# Altium schematic sheet igbt_output.SchDoc
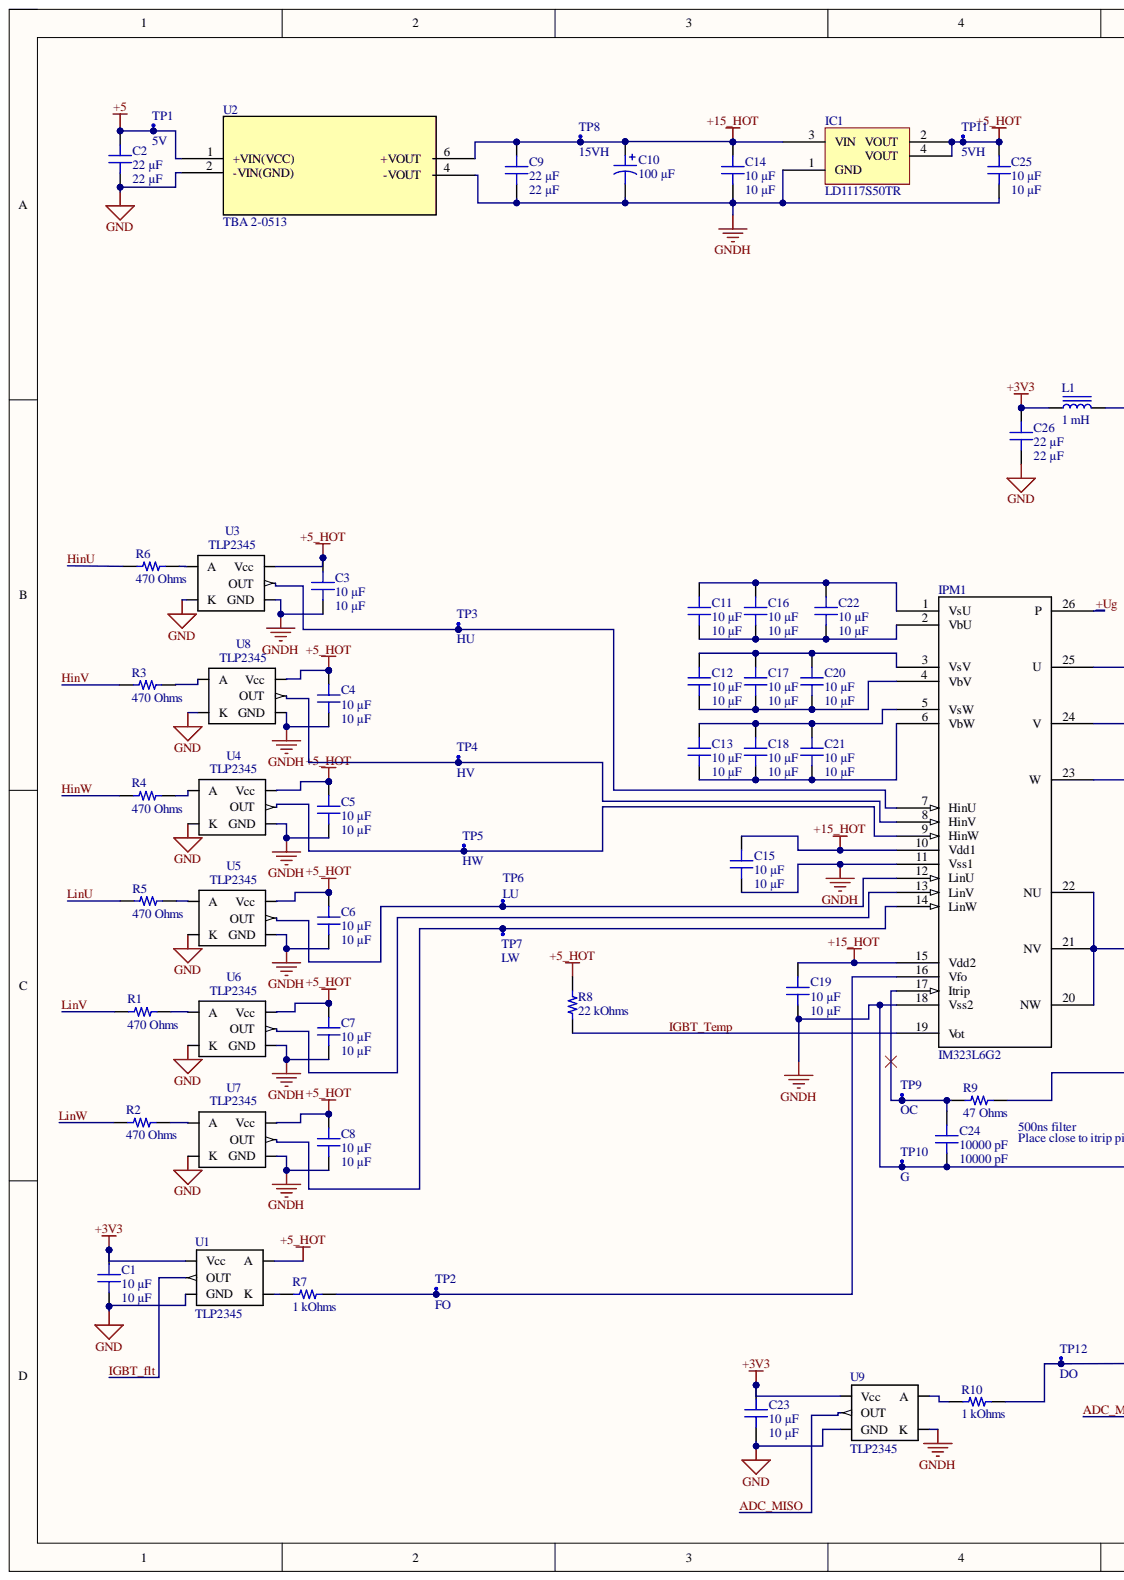
[diagram p0: igbt_output.SchDoc, left]
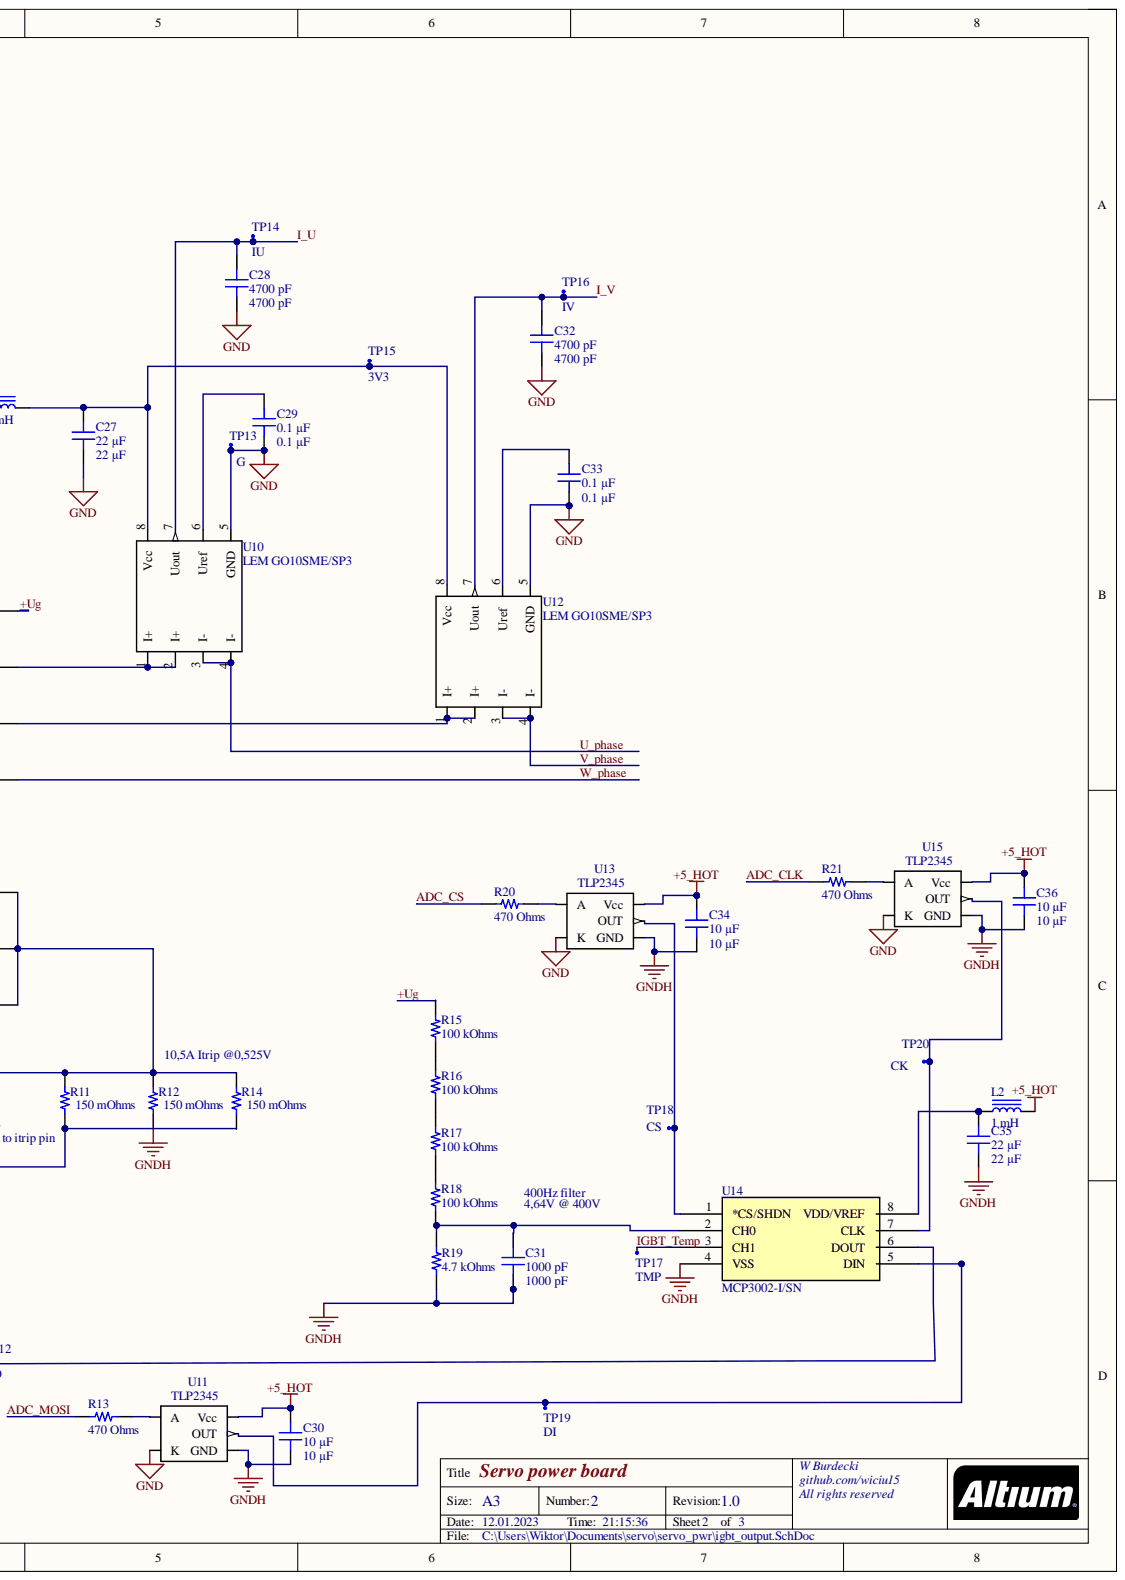
[diagram p1: igbt_output.SchDoc, right]

## Parts
C1  10 µF  [CAP 0805_2012]
C2  22 µF  [CAP 0805_2012]
C3  10 µF  [CAP 0805_2012]
C4  10 µF  [CAP 0805_2012]
C5  10 µF  [CAP 0805_2012]
C6  10 µF  [CAP 0805_2012]
C7  10 µF  [CAP 0805_2012]
C8  10 µF  [CAP 0805_2012]
C9  22 µF  [CAP 0805_2012]
C10  100 µF  [CAP AL TH D8 L3.5 H12.5]
C11  10 µF  [CAP 0805_2012]
C12  10 µF  [CAP 0805_2012]
C13  10 µF  [CAP 0805_2012]
C14  10 µF  [CAP 0805_2012]
C15  10 µF  [CAP 0805_2012]
C16  10 µF  [CAP 0805_2012]
C17  10 µF  [CAP 0805_2012]
C18  10 µF  [CAP 0805_2012]
C19  10 µF  [CAP 0805_2012]
C20  10 µF  [CAP 0805_2012]
C21  10 µF  [CAP 0805_2012]
C22  10 µF  [CAP 0805_2012]
C23  10 µF  [CAP 0805_2012]
C24  10000 pF  [CAP 0805_2012]
C25  10 µF  [CAP 0805_2012]
C26  22 µF  [CAP 0805_2012]
C27  22 µF  [CAP 0805_2012]
C28  4700 pF  [CAP 0805_2012]
C29  0.1 µF  [CAP 0805_2012]
C30  10 µF  [CAP 0805_2012]
C31  1000 pF  [CAP 0805_2012]
C32  4700 pF  [CAP 0805_2012]
C33  0.1 µF  [CAP 0805_2012]
C34  10 µF  [CAP 0805_2012]
C35  22 µF  [CAP 0805_2012]
C36  10 µF  [CAP 0805_2012]
IC1  LD1117S50TR  [ST SOT-223]
IPM1  IM323L6G2  [IM323L6G2]
L1  1 mH  [BOURNS SRR6028]
L2  1 mH  [BOURNS SRR6028]
R1  470 Ohms  [RES 0805_2012]
R2  470 Ohms  [RES 0805_2012]
R3  470 Ohms  [RES 0805_2012]
R4  470 Ohms  [RES 0805_2012]
R5  470 Ohms  [RES 0805_2012]
R6  470 Ohms  [RES 0805_2012]
R7  1 kOhms  [RES 0805_2012]
R8  22 kOhms  [RES 0805_2012]
R9  47 Ohms  [RES 0805_2012]
R10  1 kOhms  [RES 0805_2012]
R11  150 mOhms  [RES 2512_6432]
R12  150 mOhms  [RES 2512_6432]
R13  470 Ohms  [RES 0805_2012]
R14  150 mOhms  [RES 2512_6432]
R15  100 kOhms  [RES 1206_3216]
R16  100 kOhms  [RES 1206_3216]
R17  100 kOhms  [RES 1206_3216]
R18  100 kOhms  [RES 1206_3216]
R19  4.7 kOhms  [RES 1206_3216]
R20  470 Ohms  [RES 0805_2012]
R21  470 Ohms  [RES 0805_2012]
TP1  5V  [Testpoint1,2mm]
TP2  FO  [Testpoint1,2mm]
TP3  HU  [Testpoint1,2mm]
TP4  HV  [Testpoint1,2mm]
TP5  HW  [Testpoint1,2mm]
TP6  LU  [Testpoint1,2mm]
TP7  LW  [Testpoint1,2mm]
TP8  15VH  [Testpoint1,2mm]
TP9  OC  [Testpoint1,2mm]
TP10  G  [Testpoint1,2mm]
TP11  5VH  [Testpoint1,2mm]
TP12  DO  [Testpoint1,2mm]
TP13  G  [Testpoint1,2mm]
TP14  IU  [Testpoint1,2mm]
TP15  3V3  [Testpoint1,2mm]
TP16  IV  [Testpoint1,2mm]
TP17  TMP  [Testpoint1,2mm]
TP18  CS  [Testpoint1,2mm]
TP19  DI  [Testpoint1,2mm]
TP20  CK  [Testpoint1,2mm]
U1  TLP2345  [TLP2345]
U2  TBA 2-0513  [TBA 2_SINGLE_TRP]
U3  TLP2345  [TLP2345]
U4  TLP2345  [TLP2345]
U5  TLP2345  [TLP2345]
U6  TLP2345  [TLP2345]
U7  TLP2345  [TLP2345]
U8  TLP2345  [TLP2345]
U9  TLP2345  [TLP2345]
U10  LEM GO10SME/SP3  [TSOP127P600X160-8N]
U11  TLP2345  [TLP2345]
U12  LEM GO10SME/SP3  [TSOP127P600X160-8N]
U13  TLP2345  [TLP2345]
U14  MCP3002-I/SN  [SOIC8-N_MC_MCH]
U15  TLP2345  [TLP2345]

## Nets
+3V3: C1.2 C23.2 C26.2 L1.1 U1.6(Vcc) U9.6(Vcc)
+5: C2.2 TP1.1 U2.1(+VIN(VCC))
+5_HOT: C3.2 C4.2 C5.2 C6.2 C7.2 C8.2 C25.2 C30.2 C34.2 C36.2 IC1.2(VOUT) IC1.4(VOUT) L2.2 R8.2 TP11.1 U1.1(A) U3.6(Vcc) U4.6(Vcc) U5.6(Vcc) U6.6(Vcc) U7.6(Vcc) U8.6(Vcc) U11.6(Vcc) U13.6(Vcc) U15.6(Vcc)
+15_HOT: C9.2 C10.1 C14.2 C15.2 C19.2 IC1.3(VIN) IPM1.10(Vdd1) IPM1.15(Vdd2) TP8.1 U2.6(+VOUT)
GND: C1.1 C2.1 C23.1 C26.1 C27.1 C28.1 C29.1 C32.1 C33.1 TP13.1 U1.4(GND) U2.2(-VIN(GND)) U3.3(K) U4.3(K) U5.3(K) U6.3(K) U7.3(K) U8.3(K) U9.4(GND) U10.5(GND) U11.3(K) U12.5(GND) U13.3(K) U15.3(K)
GNDH: C3.1 C4.1 C5.1 C6.1 C7.1 C8.1 C9.1 C10.2 C14.1 C15.1 C19.1 C24.1 C25.1 C30.1 C31.1 C34.1 C35.1 C36.1 IC1.1(GND) IPM1.11(Vss1) IPM1.18(Vss2) R11.1 R12.1 R14.1 R19.1 TP10.1 U2.4(-VOUT) U3.4(GND) U4.4(GND) U5.4(GND) U6.4(GND) U7.4(GND) U8.4(GND) U9.3(K) U11.4(GND) U13.4(GND) U14.4(VSS) U15.4(GND)
+Ug: IPM1.26(P) R15.2
ADC_CLK: R21.1
ADC_CS: R20.1
ADC_MISO: U9.5(OUT)
ADC_MOSI: R13.1
HinU: R6.1
HinV: R3.1
HinW: R4.1
I_U: C28.2 TP14.1 U10.7(Uout)
I_V: C32.2 TP16.1 U12.7(Uout)
IGBT_flt: U1.5(OUT)
IGBT_Temp: IPM1.19(Vot) R8.1 TP17.1 U14.3(CH1)
LinU: R5.1
LinV: R1.1
LinW: R2.1
U_phase: U10.3(I-) U10.4(I-)
V_phase: U12.3(I-) U12.4(I-)
W_phase: IPM1.23(W)
NetC11_1: C11.1 C16.1 C22.1 IPM1.2(VbU)
NetC11_2: C11.2 C16.2 C22.2 IPM1.1(VsU)
NetC12_1: C12.1 C17.1 C20.1 IPM1.4(VbV)
NetC12_2: C12.2 C17.2 C20.2 IPM1.3(VsV)
NetC13_1: C13.1 C18.1 C21.1 IPM1.6(VbW)
NetC13_2: C13.2 C18.2 C21.2 IPM1.5(VsW)
NetC24_2: C24.2 IPM1.17(Itrip) R9.1 TP9.1
NetC27_2: C27.2 L1.2 TP15.1 U10.8(Vcc) U12.8(Vcc)
NetC29_2: C29.2 U10.6(Uref)
NetC31_2: C31.2 R18.1 R19.2 U14.2(CH0)
NetC33_2: C33.2 U12.6(Uref)
NetC35_2: C35.2 L2.1 U14.8(VDD/VREF)
NetIPM1_7: IPM1.7(HinU) TP3.1 U3.5(OUT)
NetIPM1_8: IPM1.8(HinV) TP4.1 U8.5(OUT)
NetIPM1_9: IPM1.9(HinW) TP5.1 U4.5(OUT)
NetIPM1_12: IPM1.12(LinU) TP6.1 U5.5(OUT)
NetIPM1_13: IPM1.13(LinV) U6.5(OUT)
NetIPM1_14: IPM1.14(LinW) TP7.1 U7.5(OUT)
NetIPM1_16: IPM1.16(Vfo) R7.2 TP2.1
NetIPM1_20: IPM1.20(NW) IPM1.21(NV) IPM1.22(NU) R9.2 R11.2 R12.2 R14.2
NetIPM1_24: IPM1.24(V) U12.1(I+) U12.2(I+)
NetIPM1_25: IPM1.25(U) U10.1(I+) U10.2(I+)
NetR1_2: R1.2 U6.1(A)
NetR2_2: R2.2 U7.1(A)
NetR3_2: R3.2 U8.1(A)
NetR4_2: R4.2 U4.1(A)
NetR5_2: R5.2 U5.1(A)
NetR6_2: R6.2 U3.1(A)
NetR7_1: R7.1 U1.3(K)
NetR10_1: R10.1 U9.1(A)
NetR10_2: R10.2 TP12.1 U14.6(DOUT)
NetR13_2: R13.2 U11.1(A)
NetR15_1: R15.1 R16.2
NetR16_1: R16.1 R17.2
NetR17_1: R17.1 R18.2
NetR20_2: R20.2 U13.1(A)
NetR21_2: R21.2 U15.1(A)
NetTP18_1: TP18.1 U13.5(OUT) U14.1(*CS/SHDN)
NetTP19_1: TP19.1 U11.5(OUT) U14.5(DIN)
NetTP20_1: TP20.1 U14.7(CLK) U15.5(OUT)

## Library data of the parts
C1 C3-C8 C11-C23 C25 C30 C34 C36 = LMK212ABJ106KGHT: CAP CER 10UF 10V X5R 0805; manufacturer: Taiyo Yuden; Database Table Name: Capacitors - Ceramic - 0805; Tolerance: ±10%; Applications: Automotive; Capacitance: 10 µF; Component Kind: Standard; componentlink1description: Datasheet; componentlink1url: https://www.yuden.co.jp/productdata/catalog/mlcc_all_hq_e.pdf; componentlink2description: DigiKey Link; componentlink2url: https://www.digikey.com/en/products/detail/taiyo-yuden/LMK212ABJ106KGHT/4157766; Device Package: 0805 (2012 Metric); lastupdated: 19.09.2022 13:04:24; Library Name: Celestial Altium Library - altium_library (1).DbLib; Lifecycle Status: Active; Minimum Order: 1; Mounting Type: Surface Mount, MLCC; Operating Temperature: -55°C ~ 85°C; Package / Case: 0805 (2012 Metric); packaging: TapeAndReel; Part Number: LMK212ABJ106KGHT; Part Status: Active; partid: 3247; price: 0.02; Ratings: AEC-Q200; series: M; Size / Dimension: 0.079" L x 0.049" W (2.00mm x 1.25mm); Supplier 1: DigiKey; Supplier Part Number 1: 587-3418-1-ND; Temperature Coefficient: X5R; Thickness (Max): 0.053" (1.35mm); Value: 10 µF; Voltage - Rated: 10V
C2 C9 C26 C27 C35 = CL21A226KOQNNNG: CAP CER 22UF 16V X5R 0805; manufacturer: Samsung Electro-Mechanics; Database Table Name: Capacitors - Ceramic - 0805; Tolerance: ±10%; Applications: General Purpose; Capacitance: 22 µF; Component Kind: Standard; componentlink1description: Datasheet; componentlink1url: //media.digikey.com/pdf/Data%20Sheets/Samsung%20PDFs/CL21A226KOQNNNG_Spec.pdf; componentlink2description: DigiKey Link; componentlink2url: https://www.digikey.com/en/products/detail/samsung-electro-mechanics/CL21A226KOQNNNG/3894433; Device Package: 0805 (2012 Metric); lastupdated: 03.01.2023 20:10:55; Library Name: Celestial.DbLib; Lifecycle Status: Active; Minimum Order: 1; Mounting Type: Surface Mount, MLCC; Operating Temperature: -55°C ~ 85°C; Package / Case: 0805 (2012 Metric); packaging: TapeAndReel; Part Number: CL21A226KOQNNNG; Part Status: Active; partid: 3000; price: 0.06; series: CL; Size / Dimension: 0.079" L x 0.049" W (2.00mm x 1.25mm); Supplier 1: DigiKey; Supplier Part Number 1: 1276-7092-1-ND; Temperature Coefficient: X5R; Thickness (Max): 0.055" (1.40mm); Value: 22 µF; Voltage - Rated: 16V
C10 = EEU-TA1E101: CAP ALUM 100UF 20% 25V RADIAL; manufacturer: Panasonic Electronic Components; Database Table Name: Capacitors - Aluminum - Electrolytic TH; Impedance: 130 mOhms; Lifetime @ Temp.: 2000 Hrs @ 125°C; Polarization: Polar; Ripple Current @ High Frequency: 500 mA @ 100 kHz; Ripple Current @ Low Frequency: 325 mA @ 120 Hz; Applications: General Purpose; Capacitance: 100 µF; Component Kind: Standard; componentlink1description: Datasheet; componentlink1url: http://industrial.panasonic.com/www-cgi/jvcr13pz.cgi?E+PZ+3+ABA0028+EEUTA1E101+8+WW; componentlink2description: DigiKey Link; componentlink2url: https://www.digikey.com/en/products/detail/panasonic-electronic-components/EEU-TA1E101/2689893; Device Package: Radial, Can; Height - Seated (Max): 0.492" (12.50mm); lastupdated: 02.01.2023 21:00:54; Lead Spacing: 0.138" (3.50mm); Library Name: Celestial.DbLib; Lifecycle Status: Active; Minimum Order: 1; Mounting Type: Through Hole; Operating Temperature: -40°C ~ 125°C; Package / Case: Radial, Can; packaging: Bulk; Part Number: EEU-TA1E101; Part Status: Active; partid: 7202; price: 0.31; series: TA; Size / Dimension: 0.315" Dia (8.00mm); Supplier 1: DigiKey; Supplier Part Number 1: P19501-ND; Tolerance: ±20%; value: 100 µF; Voltage - Rated: 25 V
C24 = C0805C103K5RECAUTO: CAP CER 0805 10NF 50V X7R 10%; manufacturer: KEMET; Database Table Name: Capacitors - Ceramic - 0805; Applications: Automotive, ESD Protection; Capacitance: 10000 pF; Component Kind: Standard; componentlink1description: Datasheet; componentlink1url: https://api.kemet.com/component-edge/download/datasheet/C0805C103K5RECAUTO.pdf; componentlink2description: DigiKey Link; componentlink2url: https://www.digikey.com/en/products/detail/kemet/C0805C103K5RECAUTO/8640479; Device Package: 0805 (2012 Metric); lastupdated: 03.01.2023 20:10:42; Library Name: Celestial.DbLib; Lifecycle Status: Active; Minimum Order: 1; Mounting Type: Surface Mount, MLCC; Operating Temperature: -55°C ~ 125°C; Package / Case: 0805 (2012 Metric); packaging: TapeAndReel; Part Number: C0805C103K5RECAUTO; Part Status: Active; partid: 8498; price: 0.04; Ratings: AEC-Q200; series: ESD SMD Auto X7R; Size / Dimension: 0.079" L x 0.049" W (2.00mm x 1.25mm); Supplier 1: DigiKey; Supplier Part Number 1: 399-17885-1-ND; Temperature Coefficient: X7R; Thickness (Max): 0.035" (0.88mm); Tolerance: ±10%; Value: 10000 pF; Voltage - Rated: 50V
C28 C32 = C0805C472K5RECAUTO: CAP CER 0805 4.7NF 50V X7R 10%; manufacturer: KEMET; Database Table Name: Capacitors - Ceramic - 0805; Applications: Automotive, ESD Protection; Capacitance: 4700 pF; Component Kind: Standard; componentlink1description: Datasheet; componentlink1url: https://api.kemet.com/component-edge/download/datasheet/C0805C472K5RECAUTO.pdf; componentlink2description: DigiKey Link; componentlink2url: https://www.digikey.com/en/products/detail/kemet/C0805C472K5RECAUTO/8640478; Device Package: 0805 (2012 Metric); lastupdated: 03.01.2023 20:10:48; Library Name: Celestial.DbLib; Lifecycle Status: Active; Minimum Order: 1; Mounting Type: Surface Mount, MLCC; Operating Temperature: -55°C ~ 125°C; Package / Case: 0805 (2012 Metric); packaging: TapeAndReel; Part Number: C0805C472K5RECAUTO; Part Status: Active; partid: 5836; price: 0.04; Ratings: AEC-Q200; series: ESD SMD Auto X7R; Size / Dimension: 0.079" L x 0.049" W (2.00mm x 1.25mm); Supplier 1: DigiKey; Supplier Part Number 1: 399-17884-1-ND; Temperature Coefficient: X7R; Thickness (Max): 0.035" (0.88mm); Tolerance: ±10%; Value: 4700 pF; Voltage - Rated: 50V
C29 C33 = CC0805MRX7R9BB104: CAP CER 0.1UF 50V X7R 0805; manufacturer: Yageo; Database Table Name: Capacitors - Ceramic - 0805; Tolerance: ±20%; Applications: General Purpose; Capacitance: 0.1 µF; Component Kind: Standard; componentlink1description: Datasheet; componentlink1url: https://www.yageo.com/upload/media/product/productsearch/datasheet/mlcc/UPY-GPHC_X7R_6.3V-to-50V_18.pdf; componentlink2description: DigiKey Link; componentlink2url: https://www.digikey.com/en/products/detail/yageo/CC0805MRX7R9BB104/5884281; Device Package: 0805 (2012 Metric); lastupdated: 03.01.2023 20:10:56; Library Name: Celestial.DbLib; Lifecycle Status: Active; Minimum Order: 1; Mounting Type: Surface Mount, MLCC; Operating Temperature: -55°C ~ 125°C; Package / Case: 0805 (2012 Metric); packaging: TapeAndReel; Part Number: CC0805MRX7R9BB104; Part Status: Active; partid: 2475; price: 0.02; series: CC; Size / Dimension: 0.079" L x 0.049" W (2.00mm x 1.25mm); Supplier 1: DigiKey; Supplier Part Number 1: 311-4351-1-ND; Temperature Coefficient: X7R; Thickness (Max): 0.037" (0.95mm); Value: 0.1 µF; Voltage - Rated: 50V
C31 = C0805X102J5HACAUTO: CAP CER 0805 1NF 50V ULTRA STABL; manufacturer: KEMET; Database Table Name: Capacitors - Ceramic - 0805; Temperature Coefficient: X8R; Tolerance: ±5%; Applications: Automotive, Boardflex Sensitive; Capacitance: 1000 pF; Component Kind: Standard; componentlink1description: Datasheet; componentlink1url: https://api.kemet.com/component-edge/download/datasheet/C0805X102J5HACAUTO.pdf; componentlink2description: DigiKey Link; componentlink2url: https://www.digikey.com/en/products/detail/kemet/C0805X102J5HACAUTO/8324462; Device Package: 0805 (2012 Metric); Features: Low ESL, Soft Termination, High Temperature; lastupdated: 03.01.2023 20:10:49; Library Name: Celestial.DbLib; Lifecycle Status: Active; Minimum Order: 1; Mounting Type: Surface Mount, MLCC; Operating Temperature: -55°C ~ 150°C; Package / Case: 0805 (2012 Metric); packaging: TapeAndReel; Part Number: C0805X102J5HACAUTO; Part Status: Active; partid: 5533; price: 0.03; Ratings: AEC-Q200; series: SMD Auto X8R HT150C Flex; Size / Dimension: 0.079" L x 0.049" W (2.00mm x 1.25mm); Supplier 1: DigiKey; Supplier Part Number 1: 399-16876-1-ND; Thickness (Max): 0.039" (0.98mm); Value: 1000 pF; Voltage - Rated: 50V
IC1 = LD1117S50TR: IC REG LINEAR 5V 800MA SOT223; Manufacturer: STMicroelectronics; Current - Quiescent (Iq): 10 mA; Database Table Name: Voltage Regulators - Linear; Number of Regulators: 1; Output Configuration: Positive; Output Type: Fixed; Protection Features: Over Current, Over Temperature; PSRR: 75dB (120Hz); Voltage - Input (Max): 15V; Voltage Dropout (Max): 1.2V @ 800mA; Component Kind: Standard; ComponentLink1Description: Datasheet; ComponentLink1URL: http://www.st.com/content/ccc/resource/technical/document/datasheet/99/3b/7d/91/91/51/4b/be/CD00000544.pdf/files/CD00000544.pdf/jcr:content/translations/en.CD00000544.pdf; ComponentLink2Description: DigiKey Link; ComponentLink2URL: https://www.digikey.com/en/products/detail/stmicroelectronics/LD1117S50TR/1038360; Current - Output: 800mA; LastUpdated: 02.01.2023 21:04:19; Library Name: Celestial.DbLib; Lifecycle Status: Active; Minimum Order: 1; Mounting Type: Surface Mount; Operating Temperature: 0°C ~ 125°C; Package / Case: TO-261-4, TO-261AA; Packaging: TapeAndReel; Part Number: LD1117S50TR; Part Status: Active; Price: 0.25; Series: -; Supplier 1: DigiKey; Supplier Device Package: SOT-223; Supplier Part Number 1: 497-6447-1-ND; Voltage - Output (Min/Fixed): 5V
L1 L2 = SRR6028-102Y: FIXED IND 1MH 150MA 4.5 OHM SMD; Manufacturer: Bourns Inc.; Current - Saturation: 170mA; Database Table Name: Inductors - Power; DC Resistance (DCR): 4.5Ohm Max; Frequency - Self Resonant: 7MHz; Inductance Frequency - Test: 100 kHz; Material - Core: Ferrite; Q @ Freq: 32 @ 252kHz; Shielding: Shielded; Tolerance: ±30%; Type: Drum Core, Wirewound; Component Kind: Standard; ComponentLink1Description: Datasheet; ComponentLink1URL: https://www.bourns.com/docs/Product-Datasheets/SRR6028.pdf; ComponentLink2Description: DigiKey Link; ComponentLink2URL: https://www.digikey.com/en/products/detail/bourns-inc/SRR6028-102Y/2563578; Current Rating (Amps): 150 mA; Device Package: Nonstandard; Height - Seated (Max): 0.118" (3.00mm); Inductance: 1 mH; LastUpdated: 02.01.2023 20:58:53; Library Name: Celestial.DbLib; Lifecycle Status: Active; Minimum Order: 1; Mounting Type: Surface Mount; Operating Temperature: -40°C ~ 125°C; Package / Case: Nonstandard; Packaging: TapeAndReel; Part Number: SRR6028-102Y; Part Status: Active; PartId: 60; Price: 0.34; Series: SRR6028; Size / Dimension: 0.268" L x 0.268" W (6.80mm x 6.80mm); Supplier 1: DigiKey; Supplier Part Number 1: SRR6028-102YCT-ND; Value: 1 mH
R1-R6 R13 R20 R21 = ERJ-PB6B4700V: RES SMD 470 OHM 0.1% 1/4W 0805; Manufacturer: Panasonic Electronic Components; Database Table Name: Resistors - Surface Mount - 0805; Power (Watts): 0.25W, 1/4W; Temperature Coefficient: ±50ppm/°C; Component Kind: Standard; ComponentLink1Description: Datasheet; ComponentLink1URL: https://industrial.panasonic.com/cdbs/www-data/pdf/RDM0000/AOA0000C328.pdf; ComponentLink2Description: DigiKey Link; ComponentLink2URL: https://www.digikey.com/en/products/detail/panasonic-electronic-components/ERJ-PB6B4700V/6213339; Composition: Thick Film; Device Package: 0805 (2012 Metric); Features: Automotive AEC-Q200, Pulse Withstanding; Height - Seated (Max): 0.028" (0.70mm); LastUpdated: 02.01.2023 20:44:49; Library Name: Celestial.DbLib; Lifecycle Status: Active; Minimum Order: 1; Number of Terminations: 2; Operating Temperature: -55°C ~ 155°C; Package / Case: 0805 (2012 Metric); Packaging: TapeAndReel; Part Number: ERJ-PB6B4700V; Part Status: Active; PartId: 28402; Price: 0.04; Ratings: AEC-Q200; Resistance: 470 Ohms; Series: ERJ-PB6; Size / Dimension: 0.079" L x 0.049" W (2.00mm x 1.25mm); Supplier 1: DigiKey; Supplier Device Package: 0805; Supplier Part Number 1: P20556CT-ND; Tolerance: ±0.1%; Value: 470 Ohms
R7 R10 = RNCS0805BKE1K00: RES 1K OHM 0.1% 1/10W 0805; Manufacturer: Stackpole Electronics Inc; Database Table Name: Resistors - Surface Mount - 0805; Power (Watts): 0.1W, 1/10W; Temperature Coefficient: ±25ppm/°C; Component Kind: Standard; ComponentLink1Description: Datasheet; ComponentLink1URL: https://www.seielect.com/catalog/sei-rncs_rnch.pdf; ComponentLink2Description: DigiKey Link; ComponentLink2URL: https://www.digikey.com/en/products/detail/stackpole-electronics-inc/RNCS0805BKE1K00/1800073; Composition: Thin Film; Device Package: 0805 (2012 Metric); Features: Anti-Corrosive, Automotive AEC-Q200, Moisture Resistant; Height - Seated (Max): 0.026" (0.65mm); LastUpdated: 02.01.2023 20:44:52; Library Name: Celestial.DbLib; Lifecycle Status: Active; Minimum Order: 1; Number of Terminations: 2; Operating Temperature: -55°C ~ 155°C; Package / Case: 0805 (2012 Metric); Packaging: TapeAndReel; Part Number: RNCS0805BKE1K00; Part Status: Active; PartId: 27715; Price: 0.09; Ratings: AEC-Q200; Resistance: 1 kOhms; Series: RNCS; Size / Dimension: 0.079" L x 0.049" W (2.00mm x 1.25mm); Supplier 1: DigiKey; Supplier Device Package: 0805; Supplier Part Number 1: RNCS0805BKE1K00CT-ND; Tolerance: ±0.1%; Value: 1 kOhms
R8 = CRGP0805F22K: RES 22K OHM 1% 1/3W 0805; Manufacturer: TE Connectivity Passive Product; Database Table Name: Resistors - Surface Mount - 0805; Power (Watts): 0.333W, 1/3W; Temperature Coefficient: ±100ppm/°C; Component Kind: Standard; ComponentLink1Description: Datasheet; ComponentLink1URL: https://www.te.com/commerce/DocumentDelivery/DDEController?Action=srchrtrv&DocNm=9-1773463-9&DocType=DS&DocLang=English; ComponentLink2Description: DigiKey Link; ComponentLink2URL: https://www.digikey.com/en/products/detail/te-connectivity-passive-product/CRGP0805F22K/8577095; Composition: Thick Film; Device Package: 0805 (2012 Metric); Features: Pulse Withstanding; Height - Seated (Max): 0.026" (0.65mm); LastUpdated: 19.09.2022 13:36:56; Library Name: Celestial Altium Library - altium_library (1).DbLib; Lifecycle Status: Active; Minimum Order: 1; Number of Terminations: 2; Operating Temperature: -55°C ~ 155°C; Package / Case: 0805 (2012 Metric); Packaging: TapeAndReel; Part Number: CRGP0805F22K; Part Status: Active; PartId: 28733; Price: 0.02; Resistance: 22 kOhms; Series: CRGP; Size / Dimension: 0.079" L x 0.049" W (2.00mm x 1.25mm); Supplier 1: DigiKey; Supplier Device Package: 0805; Supplier Part Number 1: A130493CT-ND; Tolerance: ±1%; Value: 22 kOhms
R9 = CRGP0805F47R: RES 47 OHM 1% 1/3W 0805; Manufacturer: TE Connectivity Passive Product; Database Table Name: Resistors - Surface Mount - 0805; Power (Watts): 0.333W, 1/3W; Temperature Coefficient: ±200ppm/°C; Component Kind: Standard; ComponentLink1Description: Datasheet; ComponentLink1URL: https://www.te.com/commerce/DocumentDelivery/DDEController?Action=srchrtrv&DocNm=9-1773463-9&DocType=DS&DocLang=English; ComponentLink2Description: DigiKey Link; ComponentLink2URL: https://www.digikey.com/en/products/detail/te-connectivity-passive-product/CRGP0805F47R/8577063; Composition: Thick Film; Device Package: 0805 (2012 Metric); Features: Pulse Withstanding; Height - Seated (Max): 0.026" (0.65mm); LastUpdated: 02.01.2023 20:44:48; Library Name: Celestial.DbLib; Lifecycle Status: Active; Minimum Order: 1; Number of Terminations: 2; Operating Temperature: -55°C ~ 155°C; Package / Case: 0805 (2012 Metric); Packaging: TapeAndReel; Part Number: CRGP0805F47R; Part Status: Active; PartId: 28763; Price: 0.03; Resistance: 47 Ohms; Series: CRGP; Size / Dimension: 0.079" L x 0.049" W (2.00mm x 1.25mm); Supplier 1: DigiKey; Supplier Device Package: 0805; Supplier Part Number 1: A130461CT-ND; Tolerance: ±1%; Value: 47 Ohms
R11 R12 R14 = TLRH3AWTTER150F: RES 150M OHM 1% 2W 2512; Manufacturer: KOA Speer Electronics, Inc.; Database Table Name: Resistors - Surface Mount - 2512; Temperature Coefficient: ±50ppm/°C; Component Kind: Standard; ComponentLink1Description: Datasheet; ComponentLink1URL: http://www.koaspeer.com/catimages/Products/TLRH/TLRH.pdf; ComponentLink2Description: DigiKey Link; ComponentLink2URL: http://digikey.com/product-detail/en/koa-speer-electronics-inc/TLRH3AWTTER150F/2019-TLRH3AWTTER150FCT-ND/9847534; Composition: Metal Element; Device Package: 2512 (6432 Metric); Features: Automotive AEC-Q200, Current Sense; Height - Seated (Max): 0.028" (0.70mm); LastUpdated: 02.09.2020 01:27:01; Library Name: Celestial.DbLib; Lifecycle Status: Active; Minimum Order: 1; Number of Terminations: 2; Operating Temperature: -65°C ~ 170°C; Package / Case: 2512 (6432 Metric); Packaging: TapeAndReel; Part Number: TLRH3AWTTER150F; Part Status: Active; Power (Watts): 2W; Price: 0.57; Ratings: AEC-Q200; Resistance: 150 mOhms; Series: TLRH; Size / Dimension: 0.248" L x 0.126" W (6.30mm x 3.20mm); Supplier 1: DigiKey; Supplier Device Package: 2512; Supplier Part Number 1: 2019-TLRH3AWTTER150FCT-ND; Tolerance: ±1%; Value: 150 mOhms
R15-R18 = RT1206BRB07100KL: RES SMD 100K OHM 0.1% 1/4W 1206; Manufacturer: Yageo; Database Table Name: Resistors - Surface Mount - 1206; Power (Watts): 0.25W, 1/4W; Temperature Coefficient: ±10ppm/°C; Component Kind: Standard; ComponentLink1Description: Datasheet; ComponentLink1URL: https://www.yageo.com/upload/media/product/productsearch/datasheet/rchip/PYu-RT_1-to-0.01_RoHS_L_11.pdf; ComponentLink2Description: DigiKey Link; ComponentLink2URL: https://www.digikey.com/en/products/detail/yageo/RT1206BRB07100KL/5936250; Composition: Thin Film; Device Package: 1206 (3216 Metric); Height - Seated (Max): 0.026" (0.65mm); LastUpdated: 02.01.2023 20:37:38; Library Name: Celestial.DbLib; Lifecycle Status: Active; Minimum Order: 1; Number of Terminations: 2; Operating Temperature: -55°C ~ 155°C; Package / Case: 1206 (3216 Metric); Packaging: TapeAndReel; Part Number: RT1206BRB07100KL; Part Status: Active; PartId: 26928; Price: 0.23; Resistance: 100 kOhms; Series: RT; Size / Dimension: 0.122" L x 0.063" W (3.10mm x 1.60mm); Supplier 1: DigiKey; Supplier Device Package: 1206; Supplier Part Number 1: YAG5016CT-ND; Tolerance: ±0.1%; Value: 100 kOhms
R19 = CRG1206F4K7: RES SMD 4.7K OHM 1% 1/4W 1206; Manufacturer: TE Connectivity Passive Product; Database Table Name: Resistors - Surface Mount - 1206; Power (Watts): 0.25W, 1/4W; Temperature Coefficient: ±100ppm/°C; Component Kind: Standard; ComponentLink1Description: Datasheet; ComponentLink1URL: https://www.te.com/commerce/DocumentDelivery/DDEController?Action=srchrtrv&DocNm=1773204&DocType=DS&DocLang=English; ComponentLink2Description: DigiKey Link; ComponentLink2URL: https://www.digikey.com/en/products/detail/te-connectivity-passive-product/CRG1206F4K7/2381014; Composition: Thick Film; Device Package: 1206 (3216 Metric); Height - Seated (Max): 0.026" (0.65mm); LastUpdated: 02.01.2023 20:37:38; Library Name: Celestial.DbLib; Lifecycle Status: Active; Minimum Order: 1; Number of Terminations: 2; Operating Temperature: -55°C ~ 155°C; Package / Case: 1206 (3216 Metric); Packaging: TapeAndReel; Part Number: CRG1206F4K7; Part Status: Active; PartId: 26987; Price: 0.01; Resistance: 4.7 kOhms; Series: CRG, Neohm; Size / Dimension: 0.122" L x 0.061" W (3.10mm x 1.55mm); Supplier 1: DigiKey; Supplier Device Package: 1206; Supplier Part Number 1: A106078CT-ND; Tolerance: ±1%; Value: 4.7 kOhms
TP1-TP20 = Testpoint: Component Kind: Standard; Library Name: testpoint.SchLib
U2 = TBA 2-0513: No Description Available; Mfr_Name: Traco Power; Component Kind: Standard; Digi-Key_Part_Number_1: 1951-3431-ND; Library Name: TBA2-0513.SchLib; Manufacturer_Part_Number: TBA 2-0513; RefDes: RefDes; Type: DEV; Copyright: Copyright (C) 2022 Ultra Librarian. All rights reserved.
U14 = MCP3002-I/SN: No Description Available; Mfr_Name: Microchip; Component Kind: Standard; Manufacturer_Part_Number: MCP3002-I/SN; RefDes: RefDes; Type: DEV; Copyright: Copyright (C) 2022 Ultra Librarian. All rights reserved.; Library Name: MCP3002-I&slash_SN.IntLib
IPM1 = IM323L6G2: Component Kind: Standard; Library Name: Infineon IPM.SchLib
U1 U3-U9 U11 U13 U15 = TLP2345: Component Kind: Standard; Library Name: Toshiba optocoupler.SchLib
U10 U12 = LEM GO10SME/SP3: Component Kind: Standard; Library Name: LEMGO10SME-SP3.SchLib
